# Altium schematic sheet MCU.SchDoc
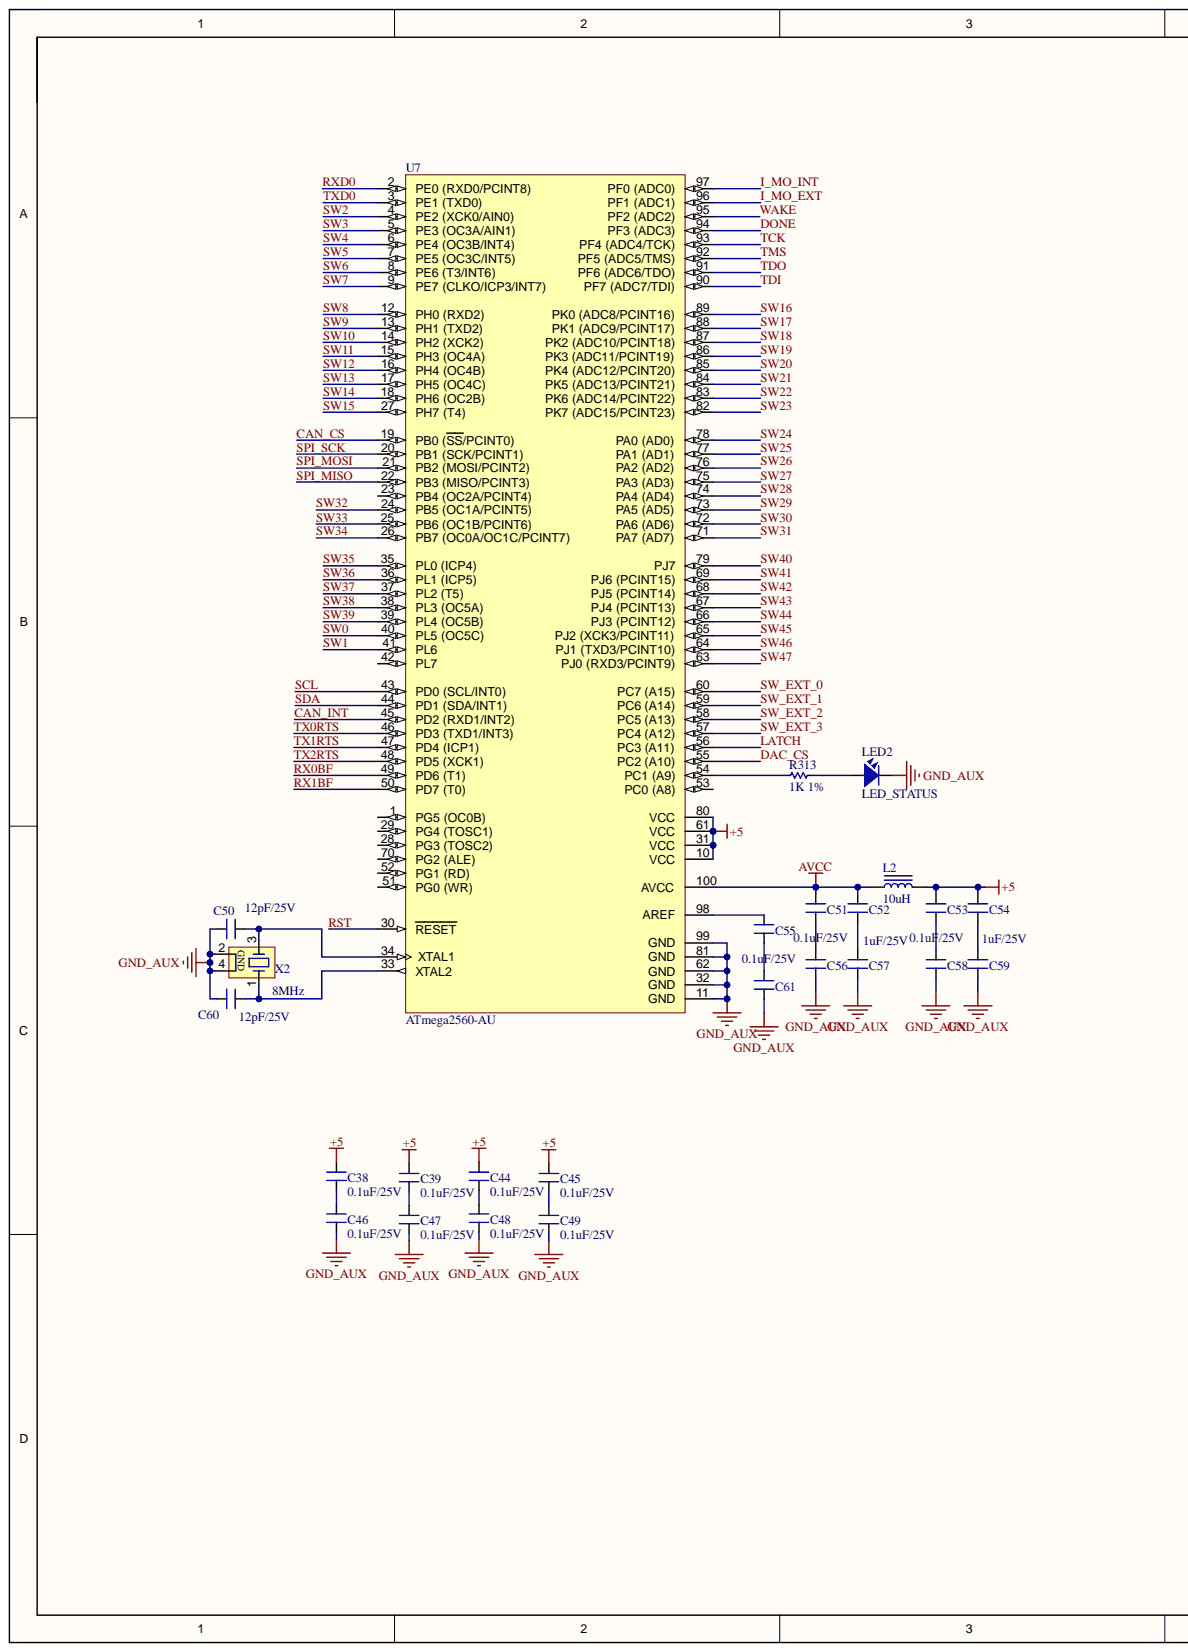
[diagram p0: MCU.SchDoc, left]
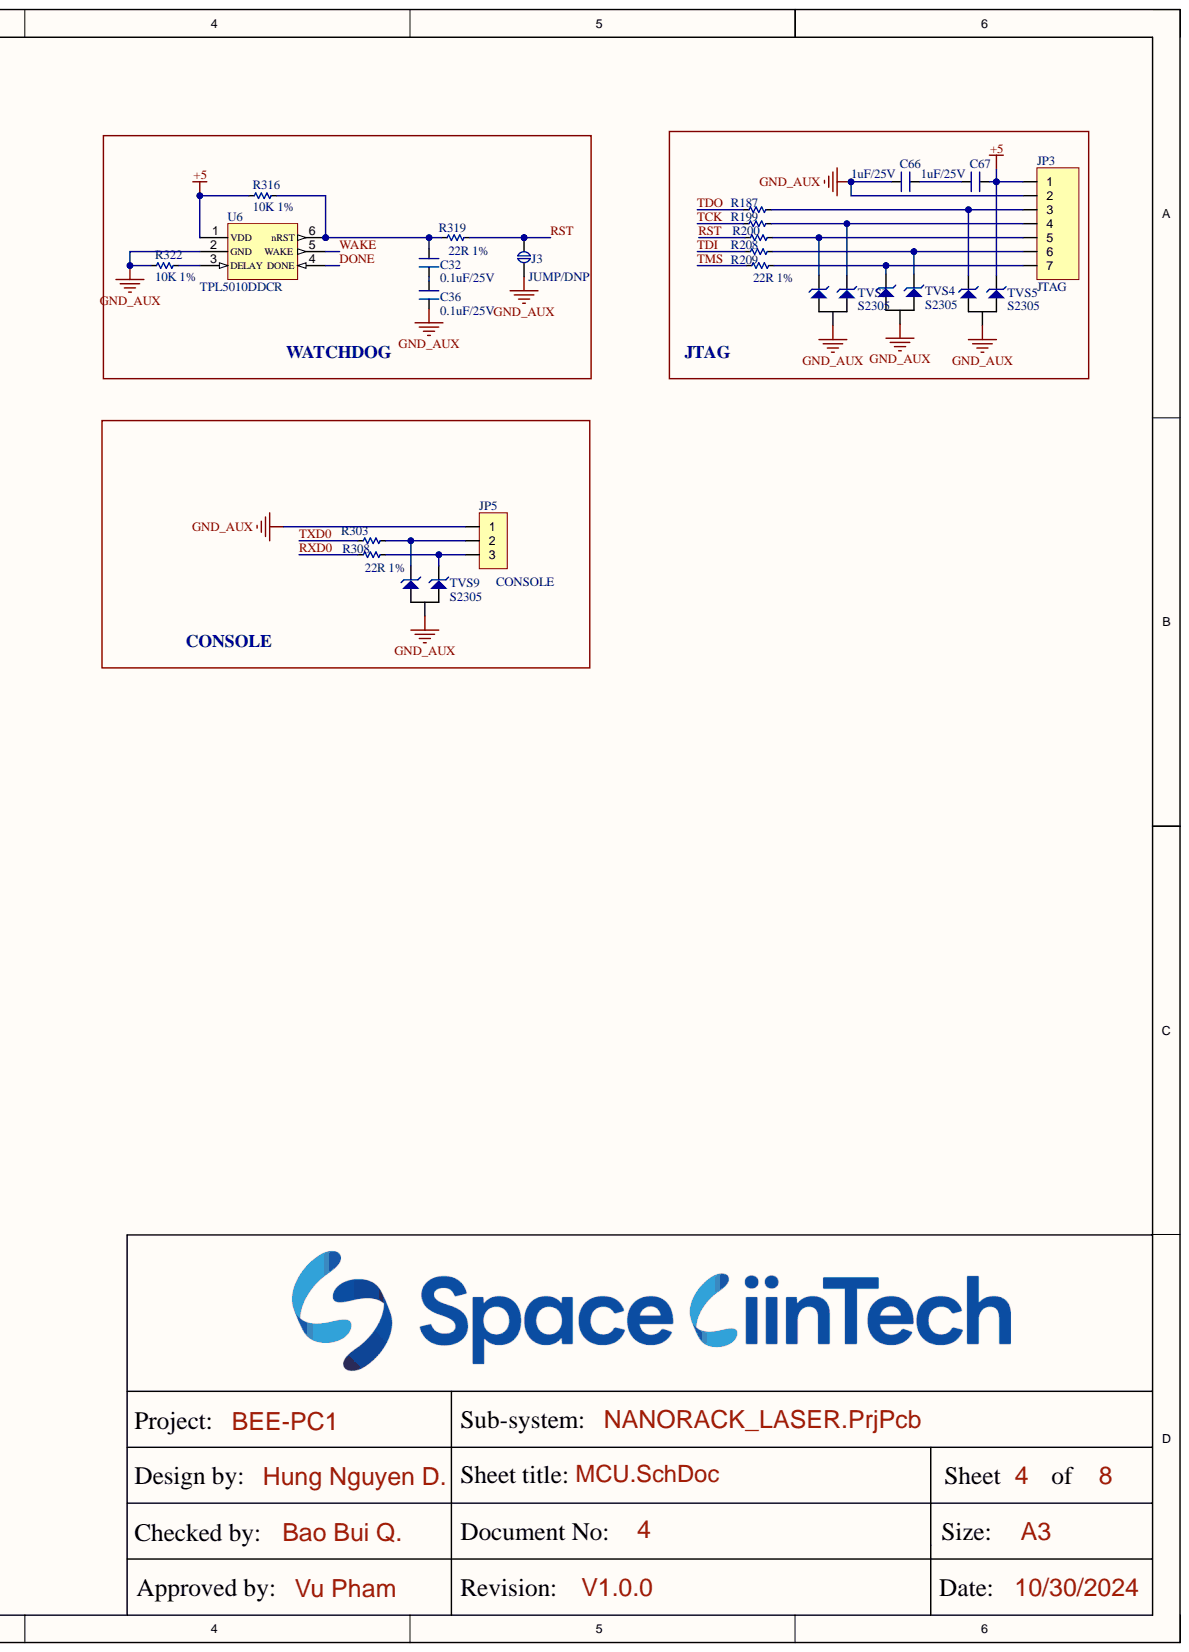
[diagram p1: MCU.SchDoc, right]

## Parts
C32  0.1uF/25V  [SM-0603]
C36  0.1uF/25V  [SM-0603]
C38  0.1uF/25V  [SM-0603]
C39  0.1uF/25V  [SM-0603]
C44  0.1uF/25V  [SM-0603]
C45  0.1uF/25V  [SM-0603]
C46  0.1uF/25V  [SM-0603]
C47  0.1uF/25V  [SM-0603]
C48  0.1uF/25V  [SM-0603]
C49  0.1uF/25V  [SM-0603]
C50  12pF/25V  [SM-0603]
C51  0.1uF/25V  [SM-0603]
C52  1uF/25V  [SM-0603]
C53  0.1uF/25V  [SM-0603]
C54  1uF/25V  [SM-0603]
C55  0.1uF/25V  [SM-0603]
C56  0.1uF/25V  [SM-0603]
C57  1uF/25V  [SM-0603]
C58  0.1uF/25V  [SM-0603]
C59  1uF/25V  [SM-0603]
C60  12pF/25V  [SM-0603]
C61  0.1uF/25V  [SM-0603]
C66  1uF/25V  [SM-0603]
C67  1uF/25V  [SM-0603]
J3  JUMP/DNP  [BIG_CONNECTOR]
JP3  JTAG  [JP7-C1C]
JP5  CONSOLE  [JP3-C1T]
L2  10uH  [FER 0603/1608]
LED2  LED_STATUS  [GREENLED_0603]
R187  22R 1%  [RES-SMD-0603]  (value not drawn)
R199  22R 1%  [RES-SMD-0603]  (value not drawn)
R200  22R 1%  [RES-SMD-0603]
R208  22R 1%  [RES-SMD-0603]
R209  22R 1%  [RES-SMD-0603]
R303  22R 1%  [RES-SMD-0603]
R308  22R 1%  [RES-SMD-0603]
R313  1K 1%  [RES-SMD-0603]
R316  10K 1%  [RES-SMD-0603]
R319  22R 1%  [RES-SMD-0603]
R322  10K 1%  [RES-SMD-0603]
TVS3  S2305  [SOT23-123]
TVS4  S2305  [SOT23-123]
TVS5  S2305  [SOT23-123]
TVS9  S2305  [SOT23-123]
U6  TPL5010DDCR  [SOT23-6]
U7  ATmega2560-AU  [TQFP100L]
X2  8MHz  [XT4-16.000MHz-4_V]

## Nets
+5: C38.1 C39.1 C44.1 C45.1 C53.2 C54.2 C67.2 JP3.1 L2.2 R316.1 TVS5.3 U6.1(VDD) U7.10(VCC) U7.31(VCC) U7.61(VCC) U7.80(VCC)
AVCC: C51.2 C52.2 L2.1 U7.100(AVCC)
GND_AUX: C36.2 C46.2 C47.2 C48.2 C49.2 C50.2 C56.1 C57.1 C58.1 C59.1 C60.2 C61.1 C66.1 J3.1 JP3.2 JP5.1 LED2.2 R322.1 TVS3.2 TVS4.2 TVS5.2 TVS9.2 U6.2(GND) U7.11(GND) U7.32(GND) U7.62(GND) U7.81(GND) U7.99(GND) X2.2 X2.4
CAN_CS: U7.19(PB0_(SS/PCINT0))
CAN_INT: U7.45(PD2_(RXD1/INT2))
DAC_CS: U7.55(PC2_(A10))
DONE: U6.4(DONE) U7.94(PF3_(ADC3))
I_MO_EXT: U7.96(PF1_(ADC1))
I_MO_INT: U7.97(PF0_(ADC0))
LATCH: U7.56(PC3_(A11))
RST: J3.2 R200.1 R319.2 U7.30(RESET)
RX0BF: U7.49(PD6_(T1))
RX1BF: U7.50(PD7_(T0))
RXD0: R308.1 U7.2(PE0_(RXD0/PCINT8))
SCL: U7.43(PD0_(SCL/INT0))
SDA: U7.44(PD1_(SDA/INT1))
SPI_MISO: U7.22(PB3_(MISO/PCINT3))
SPI_MOSI: U7.21(PB2_(MOSI/PCINT2))
SPI_SCK: U7.20(PB1_(SCK/PCINT1))
SW0: U7.40(PL5_(OC5C))
SW1: U7.41(PL6)
SW2: U7.4(PE2_(XCK0/AIN0))
SW3: U7.5(PE3_(OC3A/AIN1))
SW4: U7.6(PE4_(OC3B/INT4))
SW5: U7.7(PE5_(OC3C/INT5))
SW6: U7.8(PE6_(T3/INT6))
SW7: U7.9(PE7_(CLKO/ICP3/INT7))
SW8: U7.12(PH0_(RXD2))
SW9: U7.13(PH1_(TXD2))
SW10: U7.14(PH2_(XCK2))
SW11: U7.15(PH3_(OC4A))
SW12: U7.16(PH4_(OC4B))
SW13: U7.17(PH5_(OC4C))
SW14: U7.18(PH6_(OC2B))
SW15: U7.27(PH7_(T4))
SW16: U7.89(PK0_(ADC8/PCINT16))
SW17: U7.88(PK1_(ADC9/PCINT17))
SW18: U7.87(PK2_(ADC10/PCINT18))
SW19: U7.86(PK3_(ADC11/PCINT19))
SW20: U7.85(PK4_(ADC12/PCINT20))
SW21: U7.84(PK5_(ADC13/PCINT21))
SW22: U7.83(PK6_(ADC14/PCINT22))
SW23: U7.82(PK7_(ADC15/PCINT23))
SW24: U7.78(PA0_(AD0))
SW25: U7.77(PA1_(AD1))
SW26: U7.76(PA2_(AD2))
SW27: U7.75(PA3_(AD3))
SW28: U7.74(PA4_(AD4))
SW29: U7.73(PA5_(AD5))
SW30: U7.72(PA6_(AD6))
SW31: U7.71(PA7_(AD7))
SW32: U7.24(PB5_(OC1A/PCINT5))
SW33: U7.25(PB6_(OC1B/PCINT6))
SW34: U7.26(PB7_(OC0A/OC1C/PCINT7))
SW35: U7.35(PL0_(ICP4))
SW36: U7.36(PL1_(ICP5))
SW37: U7.37(PL2_(T5))
SW38: U7.38(PL3_(OC5A))
SW39: U7.39(PL4_(OC5B))
SW40: U7.79(PJ7)
SW41: U7.69(PJ6_(PCINT15))
SW42: U7.68(PJ5_(PCINT14))
SW43: U7.67(PJ4_(PCINT13))
SW44: U7.66(PJ3_(PCINT12))
SW45: U7.65(PJ2_(XCK3/PCINT11))
SW46: U7.64(PJ1_(TXD3/PCINT10))
SW47: U7.63(PJ0_(RXD3/PCINT9))
SW_EXT_0: U7.60(PC7_(A15))
SW_EXT_1: U7.59(PC6_(A14))
SW_EXT_2: U7.58(PC5_(A13))
SW_EXT_3: U7.57(PC4_(A12))
TCK: R199.1 U7.93(PF4_(ADC4/TCK))
TDI: R208.1 U7.90(PF7_(ADC7/TDI))
TDO: R187.1 U7.91(PF6_(ADC6/TDO))
TMS: R209.1 U7.92(PF5_(ADC5/TMS))
TX0RTS: U7.46(PD3_(TXD1/INT3))
TX1RTS: U7.47(PD4_(ICP1))
TX2RTS: U7.48(PD5_(XCK1))
TXD0: R303.1 U7.3(PE1_(TXD0))
WAKE: U6.5(WAKE) U7.95(PF2_(ADC2))
NetC32_1: C32.1 R316.2 R319.1 U6.6(nRST)
NetC32_2: C32.2 C36.1
NetC38_2: C38.2 C46.1
NetC39_2: C39.2 C47.1
NetC44_2: C44.2 C48.1
NetC45_2: C45.2 C49.1
NetC50_1: C50.1 U7.34(XTAL1) X2.3
NetC51_1: C51.1 C56.2
NetC52_1: C52.1 C57.2
NetC53_1: C53.1 C58.2
NetC54_1: C54.1 C59.2
NetC55_1: C55.1 C61.2
NetC55_2: C55.2 U7.98(AREF)
NetC60_1: C60.1 U7.33(XTAL2) X2.1
NetC66_2: C66.2 C67.1
NetJP3_3: JP3.3 R187.2 TVS5.1
NetJP3_4: JP3.4 R199.2 TVS3.3
NetJP3_5: JP3.5 R200.2 TVS3.1
NetJP3_6: JP3.6 R208.2 TVS4.3
NetJP3_7: JP3.7 R209.2 TVS4.1
NetJP5_2: JP5.2 R303.2 TVS9.1
NetJP5_3: JP5.3 R308.2 TVS9.3
NetLED2_1: LED2.1 R313.1
NetR313_2: R313.2 U7.54(PC1_(A9))
NetR322_2: R322.2 U6.3(DELAY)

Not connected: U7 1(PG5_(OC0B)) 23(PB4_(OC2A/PCINT4)) 28(PG3_(TOSC2)) 29(PG4_(TOSC1)) 42(PL7) 51(PG0_(WR)) 52(PG1_(RD)) 53(PC0_(A8)) 70(PG2_(ALE))

## Library data of the parts
C32 C36 C38 C39 C44-C61 C66 C67 = CM: 0603; Value: 103; Component Kind: Standard; Library Name: Capacitors.SchLib
J3 = CNT: DNP; Component Kind: Standard; Library Name: Library.SchLib
JP3 = JP7: WR-PHD 2.54 mm Angled Socket Header; Manufacturer: W¨¹rth Elektronik eiSos GmbH; partNo: 613007143121; ComponentLink1 Description: Manufacturer; ComponentLink2 Description: Datasheet; NoPins: 07; Component Kind: Standard; ComponentLink1URL: http://www.we-online.com; ComponentLink2URL: http://katalog.we-online.de/em/datasheet/6130xx143121.pdf; Created Date: 29 March 2013; Library Name: Library.SchLib; Created by: Karrer Zheng
JP5 = JP3: WR-PHD 2.54 mm Angled Socket Header; Manufacturer: W¨¹rth Elektronik eiSos GmbH; partNo: 613003143121; ComponentLink1 Description: Manufacturer; ComponentLink2 Description: Datasheet; NoPins: 03; Component Kind: Standard; ComponentLink1URL: http://www.we-online.com; ComponentLink2URL: http://katalog.we-online.de/em/datasheet/6130xx143121.pdf; Created Date: 29 March 2013; Library Name: Library.SchLib; Created by: Karrer Zheng
L2 = Inductor: MLZ1608N100LT000; Value: 1.5uH; Component Kind: Standard; Library Name: INDUCTOR.SchLib
LED2 = LED SMD: Value: LED 3 - 5 ly 2 Colour; Component Kind: Standard; Library Name: Library.SchLib
R187 R199 R200 R208 R209 R303 R308 R313 R316 R319 R322 = Res-SMD: 0603; Manufacturer: Generic Components; Class: Passive; Component Kind: Standard; Library Name: Library.SchLib; Published: 08/06/2000; Publisher: Altium Hobart Technology Centre
TVS3-TVS5 TVS9 = S2303: TVS DIODE 5VWM 10.8VC SOT23, P/N:    S2305; Component Kind: Standard; Library Name: Library.SchLib
U6 = TPL5010DDCR: TSOT23-6; Component Kind: Standard; Library Name: Library.SchLib
U7 = ATmega2560-AU: 8-bit AVR Microcontroller, 4.5-5.5V, 256KB Flash, 4KB EEPROM, 8KB SRAM, 86 GPIO pins, 100-pin TQFP, Industrial Grade (-40°C to 85°C), Pb-Free; Component Kind: Standard; Library Name: Library.SchLib; Supplier 1: Digi-Key; Supplier Part Number 1: ATMEGA2560-16AUR-ND
X2 = XT4: ECS-80-8-33Q-ADS; Value: 4Mhz; Component Kind: Standard; Library Name: Library.SchLib
